# Altium schematic sheet shepherd_target_nRF.SchDoc
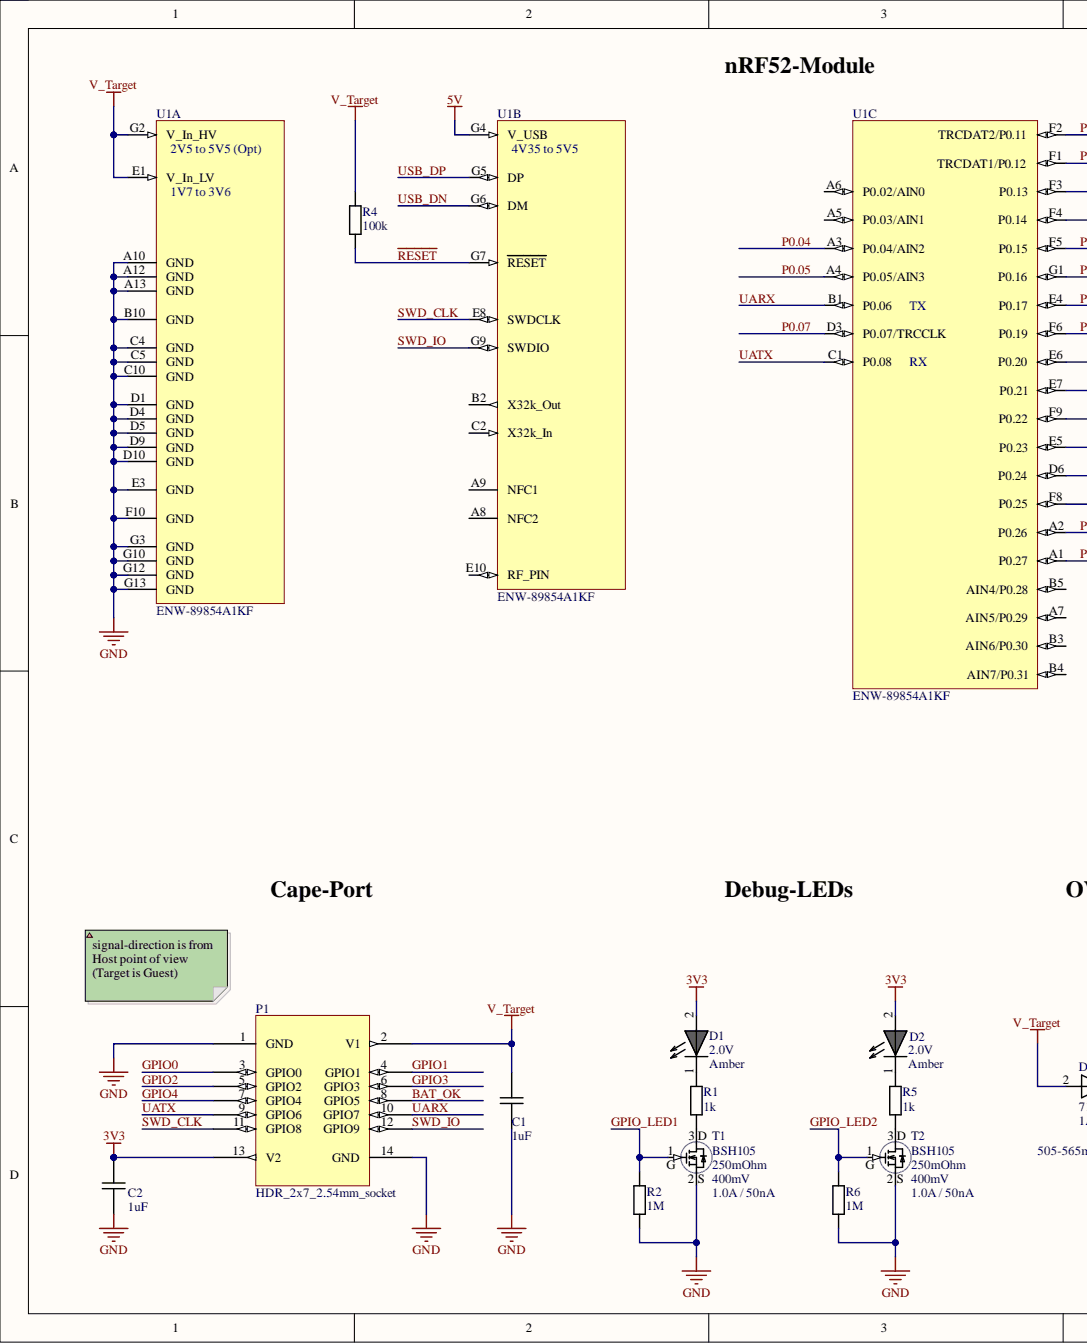
[diagram p0: shepherd_target_nRF.SchDoc, left]
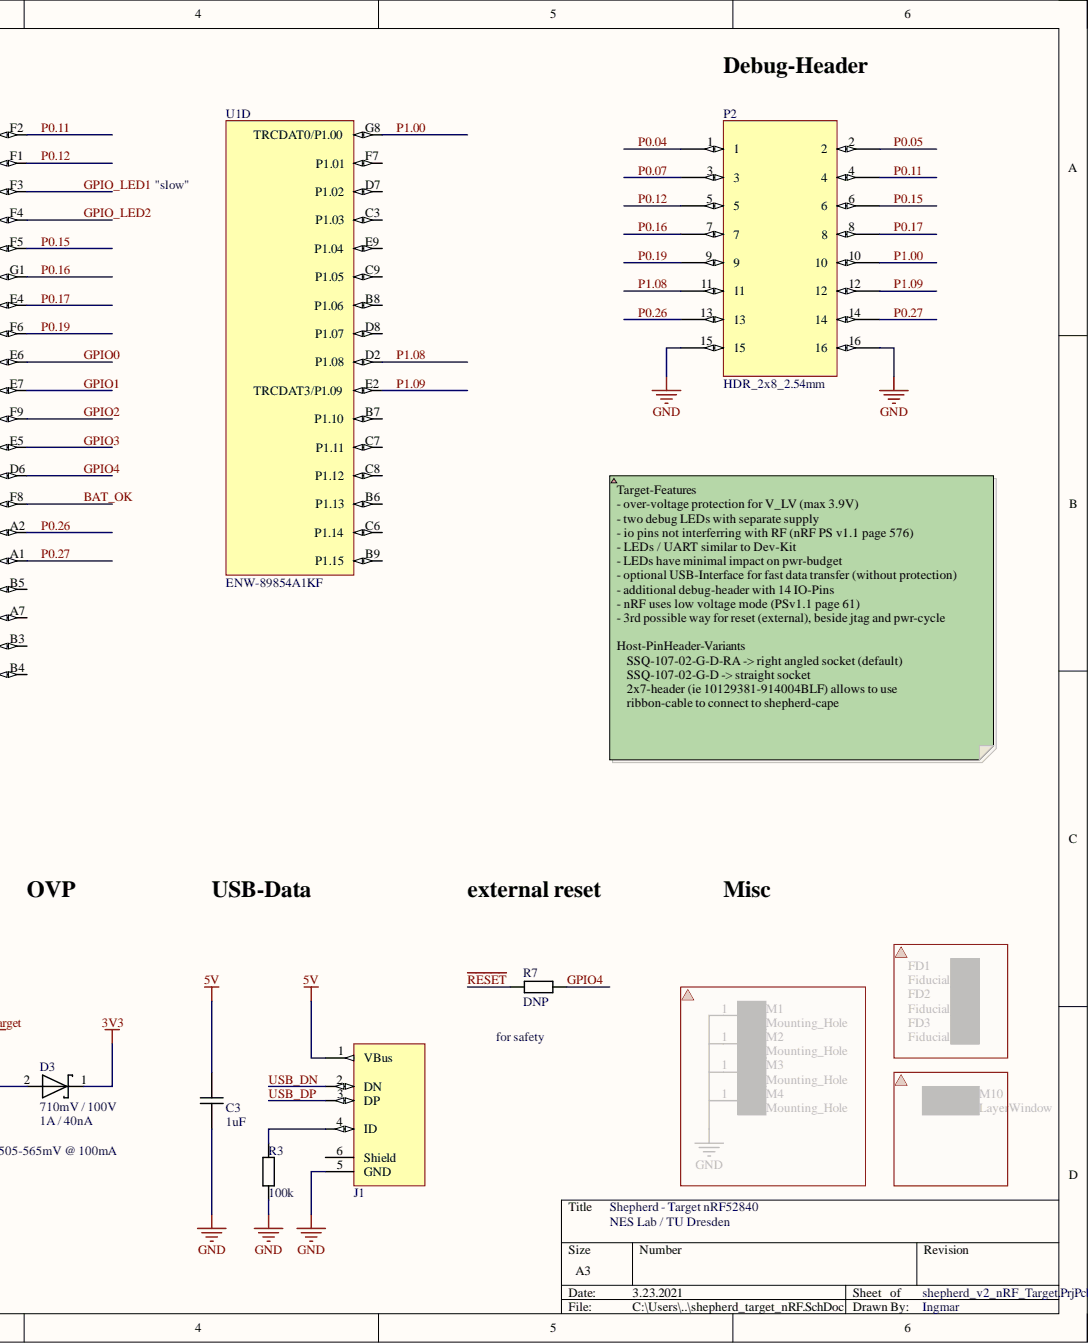
[diagram p1: shepherd_target_nRF.SchDoc, right]

## Parts
C1  value 1uF  [CAPC1005X06]  (hidden comment: C_0402_1uF_25V)
C2  value 1uF  [CAPC1005X06]  (hidden comment: C_0402_1uF_25V)
C3  value 1uF  [CAPC1005X06]  (hidden comment: C_0402_1uF_25V)
D1  value Amber  V_max: 2.0V  [DIOM1608X02N Orange]  (hidden comment: D_LED_0603_Amber)
D2  value Amber  V_max: 2.0V  [DIOM1608X02N Orange]  (hidden comment: D_LED_0603_Amber)
D3  value 710mV / 100V  I_max: 1A / 40nA  [SODFL350X110-2N]  (hidden comment: D_SOD123W_710mV_1A)
J1  J_uUSB_molex_47590-0001  [Molex_uUSB_ 47590-0001]  (value not drawn)
P1  HDR_2x7_2.54mm_socket  [P_Pinheader_2x7_R2.54mm_female]
P2  HDR_2x8_2.54mm  [P_Pinheader_2x8_R2.54mm_EdgeOut]
R1  value 1k  [RESC1005X04]  (hidden comment: R_0402_1k)
R2  value 1M  [RESC1005X04]  (hidden comment: R_0402_1M)
R3  value 100k  [RESC1005X04]  (hidden comment: R_0402_100k_1%)
R4  value 100k  [RESC1005X04]  (hidden comment: R_0402_100k_1%)
R5  value 1k  [RESC1005X04]  (hidden comment: R_0402_1k)
R6  value 1M  [RESC1005X04]  (hidden comment: R_0402_1M)
R7  value DNP  [RESC1005X04]  (hidden comment: R_0402_DNP)
T1  BSH105  R_min: 250mOhm  V_min: 400mV  [SOT95P230X110-3N]
T2  BSH105  R_min: 250mOhm  V_min: 400mV  [SOT95P230X110-3N]
U1  ENW-89854A1KF  [LGA81C110P13X7_1580X870X230]  (parts A,B,C,D)

## Nets
3V3: C2.2 D1.2 D2.2 D3.1 P1.13(V2)
5V: C3.2 J1.1(VBus) U1.G4(V_USB)
GND: C1.1 C2.1 C3.1 J1.5(GND) P1.1(GND) P1.14(GND) P2.15 P2.16 R2.1 R3.1 R6.1 T1.2 T2.2 U1.A10(GND) U1.A12(GND) U1.A13(GND) U1.B10(GND) U1.C4(GND) U1.C5(GND) U1.C10(GND) U1.D1(GND) U1.D4(GND) U1.D5(GND) U1.D9(GND) U1.D10(GND) U1.E3(GND) U1.F10(GND) U1.G3(GND) U1.G10(GND) U1.G12(GND) U1.G13(GND)
V_Target: C1.2 D3.2 P1.2(V1) R4.2 U1.E1(V_In_LV) U1.G2(V_In_HV)
BAT_OK: P1.8(GPIO5) U1.F8(P0.25)
GPIO0: P1.3(GPIO0) U1.E6(P0.20)
GPIO1: P1.4(GPIO1) U1.E7(P0.21)
GPIO2: P1.5(GPIO2) U1.F9(P0.22)
GPIO3: P1.6(GPIO3) U1.E5(P0.23)
GPIO4: P1.7(GPIO4) R7.2 U1.D6(P0.24)
GPIO_LED1: R2.2 T1.1 U1.F3(P0.13)
GPIO_LED2: R6.2 T2.1 U1.F4(P0.14)
P0.04: P2.1 U1.A3(P0.04/AIN2)
P0.05: P2.2 U1.A4(P0.05/AIN3)
P0.07: P2.3 U1.D3(P0.07/TRCCLK)
P0.11: P2.4 U1.F2(TRCDAT2/P0.11)
P0.12: P2.5 U1.F1(TRCDAT1/P0.12)
P0.15: P2.6 U1.F5(P0.15)
P0.16: P2.7 U1.G1(P0.16)
P0.17: P2.8 U1.E4(P0.17)
P0.19: P2.9 U1.F6(P0.19)
P0.26: P2.13 U1.A2(P0.26)
P0.27: P2.14 U1.A1(P0.27)
P1.00: P2.10 U1.G8(TRCDAT0/P1.00)
P1.08: P2.11 U1.D2(P1.08)
P1.09: P2.12 U1.E2(TRCDAT3/P1.09)
~{RESET}: R4.1 R7.1 U1.G7(RESET)
SWD_CLK: P1.11(GPIO8) U1.E8(SWDCLK)
SWD_IO: P1.12(GPIO9) U1.G9(SWDIO)
UARX: P1.10(GPIO7) U1.B1(P0.06)
UATX: P1.9(GPIO6) U1.C1(P0.08)
USB_DN: J1.2(DN) U1.G6(DM)
USB_DP: J1.3(DP) U1.G5(DP)
NetD1_1: D1.1 R1.2
NetD2_1: D2.1 R5.2
NetJ1_4: J1.4(ID) R3.2
NetR1_1: R1.1 T1.3
NetR5_1: R5.1 T2.3

Not connected: J1 6(Shield); U1 A5(P0.03/AIN1) A6(P0.02/AIN0) A7(AIN5/P0.29) A8(NFC2) A9(NFC1) B2(X32k_Out) B3(AIN6/P0.30) B4(AIN7/P0.31) B5(AIN4/P0.28) B6(P1.13) B7(P1.10) B8(P1.06) B9(P1.15) C2(X32k_In) C3(P1.03) C6(P1.14) C7(P1.11) C8(P1.12) C9(P1.05) D7(P1.02) D8(P1.07) E9(P1.04) E10(RF_PIN) F7(P1.01)

## Library data of the parts
C1-C3 = .C_0402_1uF_25V: Capacitor; Manufacturer: Murata; Manufacturer PN: GRM155R61E105KA12J; Dielectric: X5R; Tolerance: 10%; V_max: 25V; Component Kind: Standard; Distributer: Mouser; Distributer PN: 81-GRM155R61E105KA2J; Price n10 [€]: 0.06; Library Name: shepherd_parts.SchLib
D1 D2 = .D_LED_0603_Amber: LED Amber 610nm, 0603, 130 mcd, 2V@20mA; Manufacturer: Wuerth; Manufacturer PN: 150060AS75000; I_max: 20mA; I_typ: 5mA; V_typ: 1.9V; Component Kind: Standard; Distributer: Mouser; Distributer PN: 710-150060AS75000; Price n10 [€]: 0.12; Library Name: shepherd_parts.SchLib
D3 = .D_SOD123W_710mV_1A: Diode Si, 710mV 1A forward, 100V 40nA reverse, 50A Surge,; Manufacturer: Nexperia; Manufacturer PN: PMEG10010ELRX; Component Kind: Standard; Distributer: Mouser; Distributer PN: 771-PMEG10010ELRX; Price n10 [€]: 0.24; Library Name: shepherd_parts.SchLib
J1 = .J_uUSB_molex_47590-0001: USB Connectors MICRO USB AB RECPT; Manufacturer: Molex; I_max: 1.8A; V_max: 30V; Component Kind: Standard; Distributer: Mouser; Distributer PN: 538-47590-0001; Manufacturer PN: 47590-0001; Price n10 [€]: 0.53; Library Name: shepherd_parts.SchLib
P1 = .P_HDR_2x7_2.54mm_female: Gold, Straight; Manufacturer: Samtech; Manufacturer PN: SSQ-107-02-G-D-RA; I_max: 6.3; Component Kind: Standard; Distributer: Mouser; Distributer PN: 200-SSQ10702GDRA; Price n10 [€]: 1.95; Library Name: shepherd_parts.SchLib
P2 = .P_HDR_2x8_2.54mm: Gold, Straight; Component Kind: Standard; Distributer: Mouser; Library Name: shepherd_parts.SchLib
R1 R5 = .R_0402_1k: Resistor; Manufacturer: Panasonic; Manufacturer PN: ERJ-2GEJ102X; P_max: 100mW; Tolerance: 5%; V_max: 50V; Component Kind: Standard; Distributer: Mouser; Distributer PN: 667-ERJ-2GEJ102X; Price n10 [€]: 0.02; Library Name: shepherd_parts.SchLib
R2 R6 = .R_0402_1M: Resistor; Manufacturer: Panasonic; Manufacturer PN: ERJ-2GEJ105X; P_max: 100mW; Tolerance: 5%; V_max: 50V; Component Kind: Standard; Distributer: Mouser; Distributer PN: 667-ERJ-2GEJ105X; Price n10 [€]: 0.02; Library Name: shepherd_parts.SchLib
R3 R4 = .R_0402_100k: Resistor; Manufacturer: Panasonic; Manufacturer PN: ERJ-2RKF1003X; P_max: 100mW; Tolerance: 1%; V_max: 50V; Component Kind: Standard; Distributer: Mouser; Distributer PN: 667-ERJ-2RKF1003X; Price n10 [€]: 0.02; Library Name: shepherd_parts.SchLib
R7 = .R_0402_DNP: Resistor-Switch; P_max: 100mW; V_max: 50V; Component Kind: Standard; Distributer: Mouser; Library Name: shepherd_parts.SchLib
T1 T2 = .T_BSH105: Transistor, nMosfet, 20 V Vds, 250 mOhm Rds, +-8V Vgs, 20 ns Fall, 4.5 ns Rise, 3.9 nC Qg, 50 nA Drain Leakage (Vds=16, Vgs=0); Manufacturer: Nexperia; Manufacturer PN: BSH105,215; I_max: 1.0A / 50nA; P_max: 410mW; V_max: 20V; Component Kind: Standard; Distributer: Mouser; Distributer PN: 771-BSH105215; Price n10 [€]: 0.21; Library Name: shepherd_parts.SchLib
U1 = ENW-89854A1KF: nRF52840 Bluetooth-Module (802.15.1) PAN1780 BT LE RF Module  5x3 mm Antenna Cutout  40x40mm Groundplane; Manufacturer: Panasonic; I_max: 20mA; P_max: 8dBm; V_max: 5.5V; V_min: 1.7V; Component Kind: Standard; Distributer: Mouser; Distributer PN: 667-ENW-89854A1KF; Manufacturer PN: ENW-89854A1KF; Price n10 [€]: 9.50; Library Name: shepherd_parts.SchLib
